# Altium schematic sheet Memory_USB.SchDoc
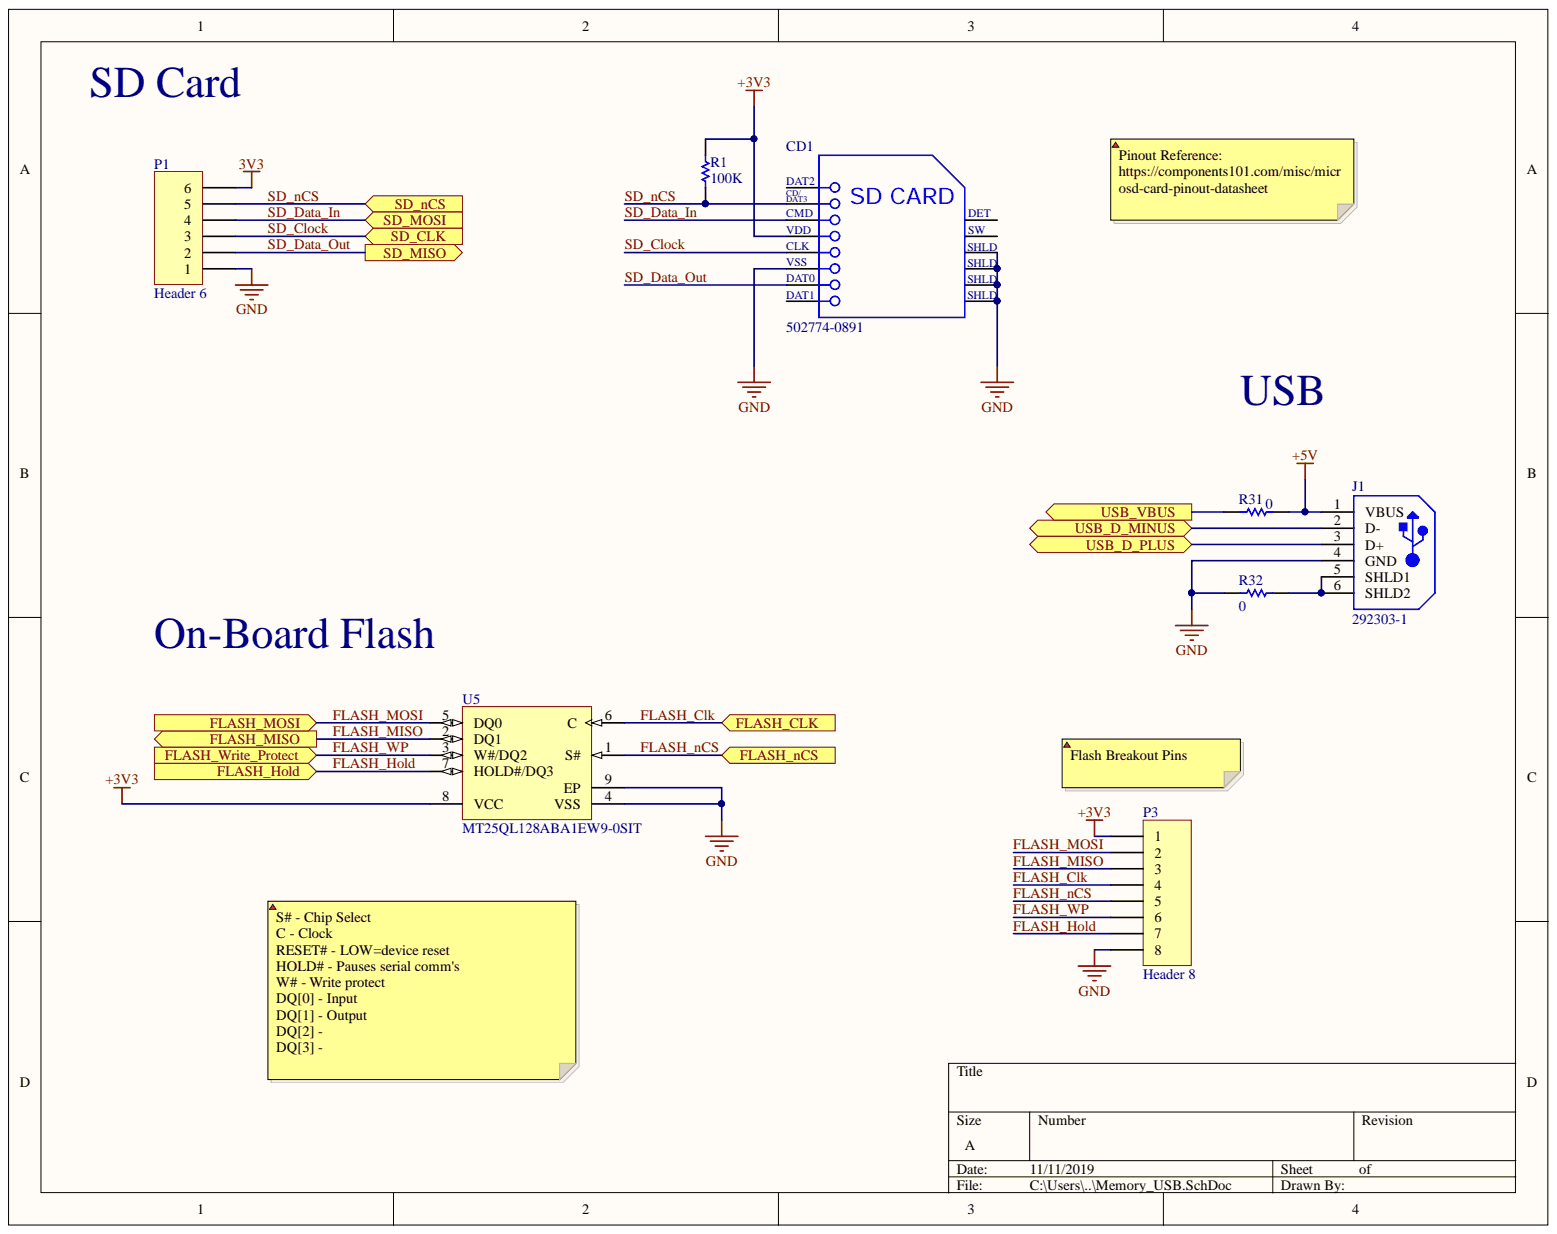
[diagram p0: Memory_USB.SchDoc]

## Parts
CD1  502774-0891  [MOLX-502774-0891_V]
J1  292303-1  [TE-292303-1_V]
P1  Header 6  [HDR1X6]
P3  Header 8  [HDR1X8]
R1  100K  [RESC1608X55X25ML10T15]
R31  0  [RESC1608X55X25ML10T15]
R32  0  [RESC1608X55X25ML10T15]
U5  MT25QL128ABA1EW9-0SIT  [MICT-W9-8_L]

## Ports
FLASH_CLK, FLASH_Hold, FLASH_MISO, FLASH_MOSI, FLASH_nCS, FLASH_Write_Protect, SD_CLK, SD_MISO, SD_MOSI, SD_nCS, USB_D_MINUS, USB_D_PLUS, USB_VBUS

## Nets
+3V3: CD1.4 P1.6 P3.1 R1.2 U5.8(VCC)
+5V: J1.1(VBUS) R31.2
GND: CD1.6 CD1.11 CD1.12 CD1.13 CD1.14 J1.4(GND) P1.1 P3.8 R32.1 U5.4(VSS) U5.9(EP)
FLASH_Clk: P3.4 U5.6(C) ; port FLASH_CLK
FLASH_Hold: P3.7 U5.7(HOLD#/DQ3) ; port FLASH_Hold
FLASH_MISO: P3.3 U5.2(DQ1) ; port FLASH_MISO
FLASH_MOSI: P3.2 U5.5(DQ0) ; port FLASH_MOSI
FLASH_nCS: P3.5 U5.1(S#) ; port FLASH_nCS
FLASH_WP: P3.6 U5.3(W#/DQ2) ; port FLASH_Write_Protect
SD_Clock: CD1.5 P1.3 ; port SD_CLK
SD_Data_In: CD1.3 P1.4 ; port SD_MOSI
SD_Data_Out: CD1.7 P1.2 ; port SD_MISO
SD_nCS: CD1.2(SD_CARD) P1.5 R1.1 ; port SD_nCS
USB_D_MINUS: J1.2(D-) ; port USB_D_MINUS
USB_D_PLUS: J1.3(D+) ; port USB_D_PLUS
USB_VBUS: R31.1 ; port USB_VBUS
NetJ1_5: J1.5(SHLD1) J1.6(SHLD2) R32.2

Not connected: CD1 1 8 9 10

## Library data of the parts
CD1 = CMP-2000-05248-1: Micro SD Memory Card Connector, 0.5 A, 10 V (DC), -25 to 85 degC, 8-Pin SMT, RoHS, Tape and Reel; Manufacturer: Molex; 3D Model: 502774-0891.stp; Card Type: SecureDigital-microSD™; Connector Type: ConnectorandEjector; Insertion, Removal Method: PushIn,PushOut; Number of Positions: 10(8+2); Online Catalog: microSD™Series; Other Names: WM24066DKR; Catalog Drawings: 502774-0891; Category: Connectors,Interconnects; Component Kind: Standard; Contact Finish: Gold; Contact Finish Thickness: 20µin(0.51µm); Datasheet URL: https://datasheet.ciiva.com/1104/1499551-1104671.pdf; Datasheet Version: Rev. D, 04/2004; Ejector Side: -; Family: MemoryConnectors-PCCardSockets; Features: Switch; Height Above Board: 0.071"(1.80mm); Library Name: Altium Content Vault; Manufacturer URL: http://www.molex.com/; Mounting Feature: Reverse-Bottom; Mounting Type: SurfaceMount,RightAngle; Packaging: Digi-Reel; RoHS Information: 502774-0891CertofCompliance; Series: 502774; Standard Package: 1
J1 = CMP-2000-05404-1: 1 Port Right Angle Through Hole Mount Female Type A USB Connector, 4 Position, -55 to 85 degC, RoHS, Tube; Manufacturer: TE Connectivity; Connector Mounting: PCB; Connector Type: USBA; Contact Termination Type: Solder; Gender: Receptacle; No. of Contacts: 4; SVHC: NoSVHC(16-Jun-2014); Component Kind: Standard; Contact Material: Copper; Contact Plating: Gold; Datasheet URL: http://www.farnell.com/cad/1653030.pdf; Datasheet Version: Feb-12; Library Name: Altium Content Vault; Manufacturer URL: http://www.te.com/; Package Description: 4-Pin Through Hole Device, Body 14 x 13.13 mm, Pitch 2.5 mm; Package Reference: 292303-1
P1 = Header 6: Header, 6-Pin; Component Kind: Standard; LatestRevisionDate: 17-Jul-2002; LatestRevisionNote: Re-released for DXP Platform.; Library Name: Miscellaneous Connectors.IntLib; Signal Integrity: Connector; Publisher: Altium Limited
P3 = Header 8: Header, 8-Pin; Component Kind: Standard; LatestRevisionDate: 17-Jul-2002; LatestRevisionNote: Re-released for DXP Platform.; Library Name: Miscellaneous Connectors.IntLib; Signal Integrity: Connector; Publisher: Altium Limited
R1 = CMP-2100-03662-1: Chip Resistor, 100 KOhm, +/- 1%, 0.1 W, -55 to 155 degC, 0603 (1608 Metric), RoHS, Tape and Reel; Manufacturer: Yageo; Power Rating: 100mW; Temperature Coefficient: 100ppm/degreesC; Voltage Rating: 50V; Case/Package: 0603; Case Code (Imperial): 0603; Case Code (Metric): 1608; Component Kind: Standard; Composition: ThickFilm; Datasheet URL: http://www.yageo.com/NewPortal/yageodocoutput?fileName=/pdf/R-Chip/PYu-RC_Group_51_RoHS_L_5.pdf; Datasheet Version: Rev. 5, 10/2016; Depth: 0.8mm; Height: 0.45mm; Lead Free: LeadFree; Length: 1.6mm; Library Name: Altium Content Vault; Manufacturer URL: http://www.yageo.com/; Max Operating Temperature: 155degC; Military Standard: Not; Min Operating Temperature: -55degC; Number of Pins: 2; Package Description: 2-Pin Surface Mount Device, Body 1.6 x 0.8 mm; Package Reference: 0603; Packaging: TapeandReel; REACH SVHC: NoSVHC; Resistance: 100KOhm; RoHSCompliant: true; Termination: SMD/SMT; Tolerance: 1%; Voltage Rating (DC): 50V; Width: 0.8mm
R31 R32 = CMP-2100-03667-1: Chip Resistor, 0 Ohm, +/- 5%, 0.1 W, -55 to 155 degC, 0603 (1608 Metric), RoHS, Tape and Reel; Manufacturer: Yageo; Power Rating: 100mW; Resistance: 0mOhm; Temperature Coefficient: 200ppm/degreesC; Voltage Rating: 50V; Case/Package: 0603; Case Code (Imperial): 0603; Case Code (Metric): 1608; Component Kind: Standard; Composition: ThickFilm; Contact Plating: Tin; Datasheet URL: http://www.yageo.com/NewPortal/yageodocoutput?fileName=/pdf/R-Chip/PYu-RC_Group_51_RoHS_L_5.pdf; Datasheet Version: Rev. 5, 10/2016; Depth: 0.8mm; Height: 0.45mm; Lead Free: LeadFree; Length: 1.6mm; Library Name: Altium Content Vault; Manufacturer URL: http://www.yageo.com/; Max Operating Temperature: 155degC; Military Standard: Not; Min Operating Temperature: -55degC; Number of Pins: 2; Package Description: 2-Pin Surface Mount Device, Body 1.6 x 0.8 mm; Package Quantity: 5000; Package Reference: 0603; Packaging: TapeandReel; Radiation Hardening: No; REACH SVHC: NoSVHC; RoHSCompliant: true; Termination: SMD/SMT; Tolerance: 5%; Voltage Rating (DC): 50V; Width: 0.8mm
U5 = CMP-2000-07470-1: Micron Serial NOR Flash Memory, 2.7 to 3.6 V, 128 Mb, -40 to 85 degC, 8-Pin W-PDFN (W9), RoHS, Tape and Reel; Component Kind: Standard; Datasheet URL: https://www.micron.com/~/media/documents/products/data-sheet/nor-flash/serial-nor/mt25q/die-rev-a/mt25q_qlhs_l_128_aba_0.pdf; Datasheet Version: Rev. I , 09/2016; Library Name: Altium Content Vault; Manufacturer: Micron; Manufacturer URL: http://www.micron.com/; Mounting Technology: SurfaceMount; Package Description: 8-Pin Plastic Dual Flat No-lead Package, Body 8 x 6 mm, Pitch 1.27 mm; Package Reference: W9; RoHSCompliant: false
